# Altium schematic sheet SCM20260D-Connectors.SchDoc(SCM20260D-Connectors)
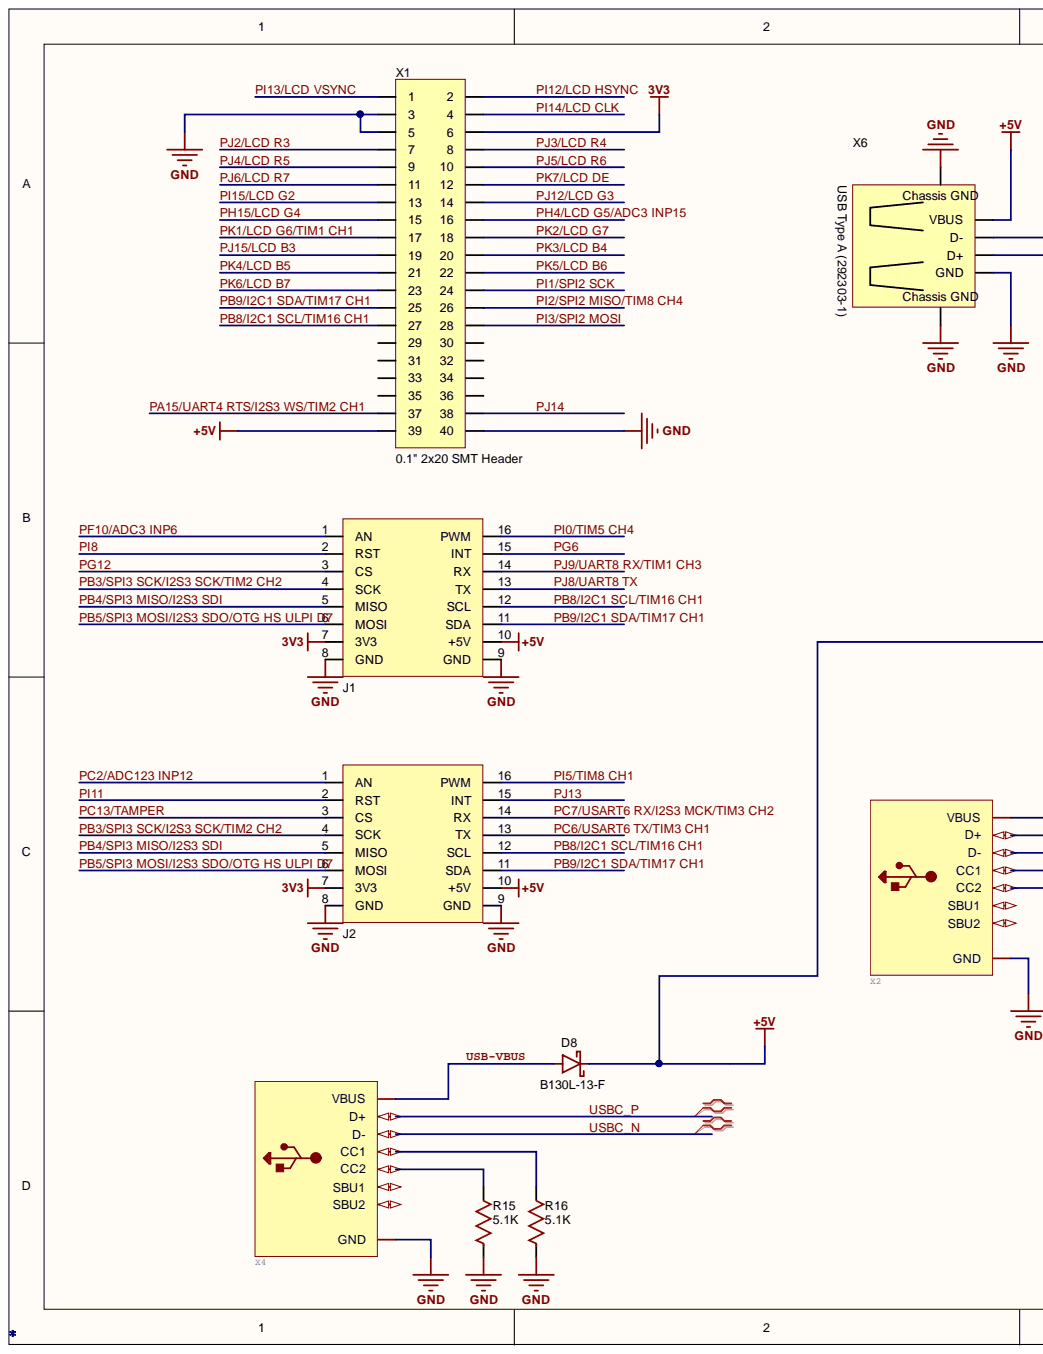
[diagram p0: SCM20260D-Connectors.SchDoc, left]
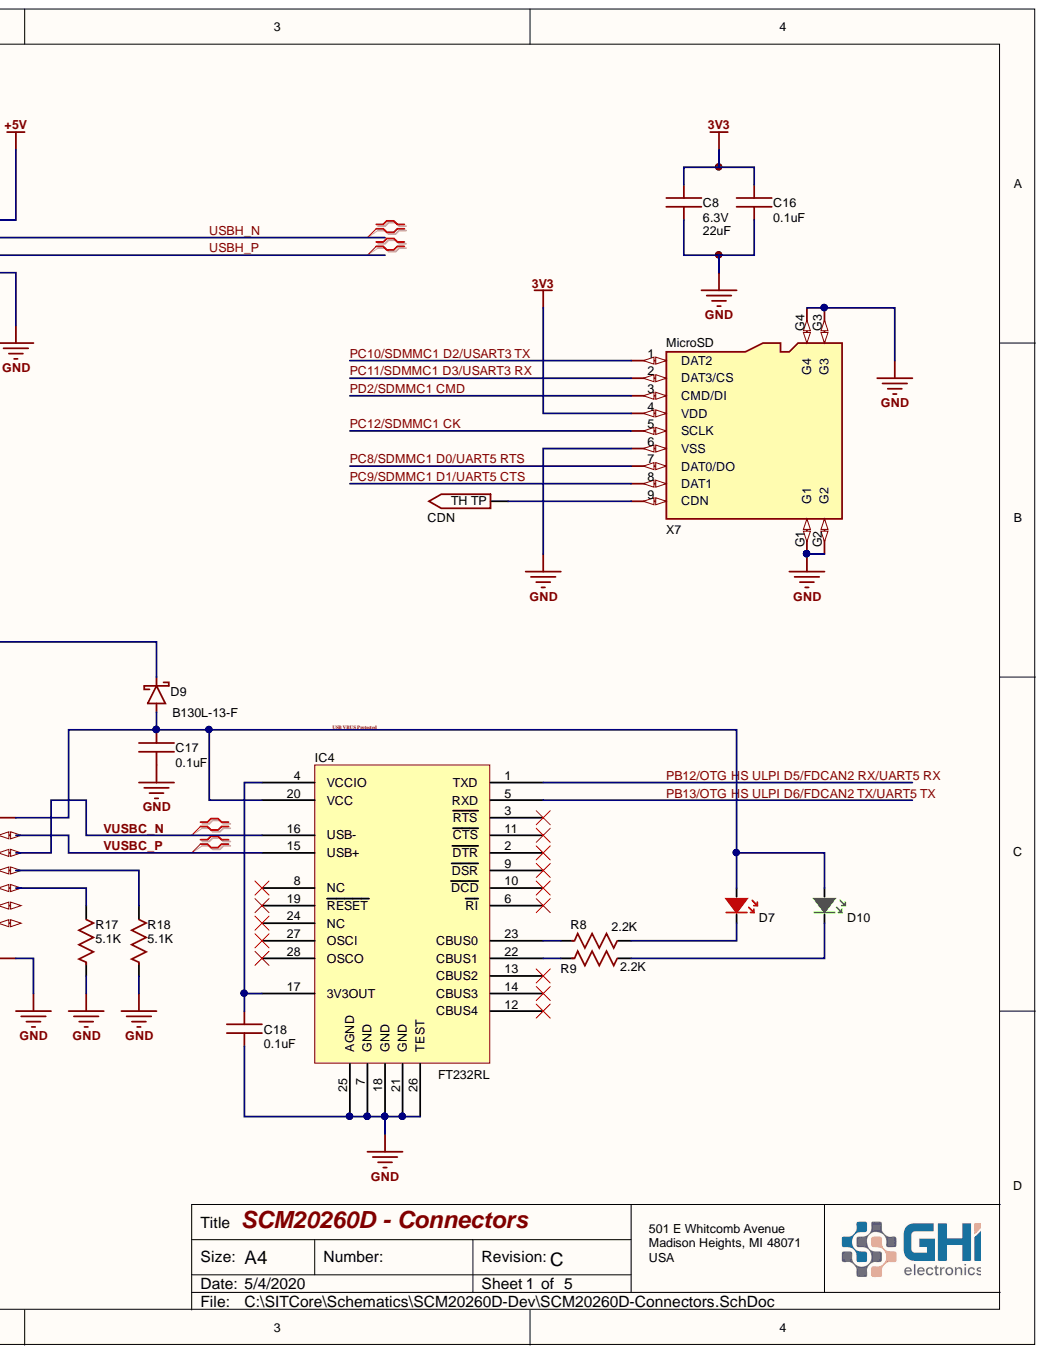
[diagram p1: SCM20260D-Connectors.SchDoc, right]

## Parts
C8  value 22uF  Voltage: 6.3V  [C0805]  (hidden comment: 0805 Cap 22uF)
C16  value 0.1uF  [C0603 (1608 Metric)]  (hidden comment: 0603 Cap 0.1uF)
C17  value 0.1uF  [C0603 (1608 Metric)]  (hidden comment: 0603 Cap 0.1uF)
C18  value 0.1uF  [C0603 (1608 Metric)]  (hidden comment: 0603 Cap 0.1uF)
CDN  TH Testpad 32mil (32mil ar)  [32mil TH]  (value not drawn)  (no BOM)
D7  0603 LED Red  [0603 LED Red]  (value not drawn)
D8  B130L-13-F  [SMA]
D9  B130L-13-F  [SMA]
D10  0603 LED Green  [0603 LED Green]  (value not drawn)
IC4  FT232RL  [SSOP28]
J1  mikroBUS SMT  [mikroBus - SMT]  (value not drawn)
J2  mikroBUS SMT  [mikroBus - SMT]  (value not drawn)
R8  value 2.2K  [R0603 (1608 Metric)]  (hidden comment: 0603 2.2K)
R9  value 2.2K  [R0603 (1608 Metric)]  (hidden comment: 0603 2.2K)
R15  value 5.1K  [R0402]  (hidden comment: 0402 Res 5.1K)
R16  value 5.1K  [R0402]  (hidden comment: 0402 Res 5.1K)
R17  value 5.1K  [R0402]  (hidden comment: 0402 Res 5.1K)
R18  value 5.1K  [R0402]  (hidden comment: 0402 Res 5.1K)
X1  0.1" 2x20 SMT Header  [UDM435]
X2  (no value)  [GCT_USB4105_revA]
X4  (no value)  [GCT_USB4105_revA]
X6  USB Type A (292303-1)  [AMP 292303-1]
X7  MICROSD  [MICROSD]

## Nets
3V3: C8.2 C16.2 J1.7(3V3) J2.7(3V3) X1.6 X7.4(VDD)
+5V: D8.K D9.K J1.10(+5V) J2.10(+5V) X1.39 X6.1(VBUS)
GND: C8.1 C16.1 C17.1 C18.1 IC4.7(GND) IC4.18(GND) IC4.21(GND) IC4.25(AGND) IC4.26(TEST) J1.8(GND) J1.9(GND) J2.8(GND) J2.9(GND) R15.1 R16.1 R17.1 R18.1 X1.3 X1.5 X1.40 X2.GND X4.GND X6.4(GND) X6.CG1 X6.CG2 X7.6(VSS) X7.G1 X7.G2 X7.G3 X7.G4
PA15/UART4 RTS/I2S3 WS/TIM2 CH1: X1.37
PB3/SPI3 SCK/I2S3 SCK/TIM2 CH2: J1.4(SCK) J2.4(SCK)
PB4/SPI3 MISO/I2S3 SDI: J1.5(MISO) J2.5(MISO)
PB5/SPI3 MOSI/I2S3 SDO/OTG HS ULPI D7: J1.6(MOSI) J2.6(MOSI)
PB8/I2C1 SCL/TIM16 CH1: J1.12(SCL) J2.12(SCL) X1.27
PB9/I2C1 SDA/TIM17 CH1: J1.11(SDA) J2.11(SDA) X1.25
PB12/OTG HS ULPI D5/FDCAN2 RX/UART5 RX: IC4.1(TXD)
PB13/OTG HS ULPI D6/FDCAN2 TX/UART5 TX: IC4.5(RXD)
PC2/ADC123 INP12: J2.1(AN)
PC6/USART6 TX/TIM3 CH1: J2.13(TX)
PC7/USART6 RX/I2S3 MCK/TIM3 CH2: J2.14(RX)
PC8/SDMMC1 D0/UART5 RTS: X7.7(DAT0/DO)
PC9/SDMMC1 D1/UART5 CTS: X7.8(DAT1)
PC10/SDMMC1 D2/USART3 TX: X7.1(DAT2)
PC11/SDMMC1 D3/USART3 RX: X7.2(DAT3/CS)
PC12/SDMMC1 CK: X7.5(SCLK)
PC13/TAMPER: J2.3(CS)
PD2/SDMMC1 CMD: X7.3(CMD/DI)
PF10/ADC3 INP6: J1.1(AN)
PG6: J1.15(INT)
PG12: J1.3(CS)
PH4/LCD G5/ADC3 INP15: X1.16
PH15/LCD G4: X1.15
PI0/TIM5 CH4: J1.16(PWM)
PI1/SPI2 SCK: X1.24
PI2/SPI2 MISO/TIM8 CH4: X1.26
PI3/SPI2 MOSI: X1.28
PI5/TIM8 CH1: J2.16(PWM)
PI8: J1.2(RST)
PI11: J2.2(RST)
PI12/LCD HSYNC: X1.2
PI13/LCD VSYNC: X1.1
PI14/LCD CLK: X1.4
PI15/LCD G2: X1.13
PJ2/LCD R3: X1.7
PJ3/LCD R4: X1.8
PJ4/LCD R5: X1.9
PJ5/LCD R6: X1.10
PJ6/LCD R7: X1.11
PJ8/UART8 TX: J1.13(TX)
PJ9/UART8 RX/TIM1 CH3: J1.14(RX)
PJ12/LCD G3: X1.14
PJ13: J2.15(INT)
PJ14: X1.38
PJ15/LCD B3: X1.19
PK1/LCD G6/TIM1 CH1: X1.17
PK2/LCD G7: X1.18
PK3/LCD B4: X1.20
PK4/LCD B5: X1.21
PK5/LCD B6: X1.22
PK6/LCD B7: X1.23
PK7/LCD DE: X1.12
USB VBUS Protected: C17.2 D7.2 D9.A D10.2 IC4.20(VCC) X2.A4B9(VBUS)
USB-VBUS: D8.A X4.A4B9(VBUS)
USBC_N: X4.A7B7(D-)
USBC_P: X4.A6B6(D+)
USBH_N: X6.2(D-)
USBH_P: X6.3(D+)
VUSBC_N: IC4.16(USB-) X2.A7B7(D-)
VUSBC_P: IC4.15(USB+) X2.A6B6(D+)
NetC18_2: C18.2 IC4.4(VCCIO) IC4.17(3V3OUT)
NetCDN_1: CDN.1 X7.9(CDN)
NetD7_1: D7.1 R8.2
NetD10_1: D10.1 R9.2
NetIC4_22: IC4.22(CBUS1) R9.1
NetIC4_23: IC4.23(CBUS0) R8.1
NetR15_2: R15.2 X4.B5(CC2)
NetR16_2: R16.2 X4.A5(CC1)
NetR17_2: R17.2 X2.B5(CC2)
NetR18_2: R18.2 X2.A5(CC1)

Not connected: IC4 2(DTR) 3(RTS) 6(RI) 8(NC) 9(DSR) 10(DCD) 11(CTS) 12(CBUS4) 13(CBUS2) 14(CBUS3) 19(RESET) 24(NC) 27(OSCI) 28(OSCO); X1 29 30 31 32 33 34 35 36; X2 A8(SBU1) B8(SBU2); X4 A8(SBU1) B8(SBU2)

## Library data of the parts
C8 = 0805 Cap 22uF: 22uF ±20% 6.3V X5R 0805 Multilayer Ceramic Capacitors MLCC - SMD/SMT RoHS; Mfr.Part #: CL21A226MQCLRNC; Tolerance: ±20%; Package: 0805; LCSC Part #: C307530; Component Kind: Standard; Category: Ceramic; Capacitance: 22uF; Library Name: GHI-Passives.SchLib
C16-C18 = 0603 Cap 0.1uF: 0.1uF ±5% 50V X7R 0603 Multilayer Ceramic Capacitors MLCC - SMD/SMT RoHS; Mfr.Part #: CL10B104JB8NNNC; Voltage: 50V; Tolerance: ±5%; Package: 0603; LCSC Part #: C24452; Digikey: 1276-1033-1-ND; Component Kind: Standard; Category: Ceramic; Capacitance: 0.1uF; Library Name: GHI-Passives.SchLib
CDN = TH Testpad 32mil (32mil ar): TH Testpad 32mil (32mil annular ring); Library Name: GHI.SchLib
D7 = 0603 LED Red: Red 631nm 0603 Light Emitting Diodes (LED) RoHS; Mfr.Part #: LTST-C193KRKT-5A; Manufacturer: Lite-On; Value: 0603 LED Red; Package: 0603; LCSC Part #: C157741; Component Kind: Standard; Color: Red; Library Name: GHI-Opto.SchLib
D8 D9 = B130L-13-F 1A Schottky SMA: 30V 1A 410mV @ 1A SMA(DO-214AC) Schottky Barrier Diodes (SBD) RoHS; Manufacturer: Diodes Incorporated; GHI Part Number: EPC-DB130L-13; Value: B130L-13-F; Package: SMA(DO-214AC); Mfr.Part #: B130L-13-F; Library Name: GHI.SchLib; Component Type: Diode; Component Kind: Standard
D10 = 0603 LED Green: Green 567.5~576.5nm 0603 Light Emitting Diodes (LED) RoHS; Mfr.Part #: LTST-C193KGKT-5A; Manufacturer: Lite-On; Value: 0603 LED Green; Package: 0603; LCSC Part #: C125102; Component Kind: Standard; Color: Green; Library Name: GHI-Opto.SchLib
IC4 = FT232RL: Future Technology Devices International Ltd. FT232R USB UART IC; Value: FT232RL; PartNo: FT232RL; Component Kind: Standard; Library Name: GHI IC.SchLib
J1 J2 = mikroBUS SMT: Value: 218108M141; PartNo: 218108M141; Component Kind: Standard; Library Name: GHI.SchLib
R8 R9 = 0603 Res 2.2K: 2.2K ±1% 1/10W ±100ppm/℃ 0603 Chip Resistor - Surface Mount RoHS; Mfr.Part #: RC0603FR-072K2L; Manufacturer: YAGEO; Voltage Rating: *V; Resistance Tolerance: ±1%; Resistance: 2.2K; Power Rating: 1/10W; Package: 0603; LCSC Part #: C114662; Component Kind: Standard; Library Name: GHI-Passives.SchLib
R15-R18 = 0402 Res 5.1K: 5.1K ±1% 1/16W ±100ppm/℃ 0402 Chip Resistor - Surface Mount RoHS; Mfr.Part #: RC0402FR-075K1L; Manufacturer: YAGEO; Voltage Rating: *V; Resistance Tolerance: ±1%; Resistance: 5.1K; Power Rating: 1/16W; Package: 0402; LCSC Part #: C105872; Component Kind: Standard; Library Name: GHI-Passives.SchLib
X1 = 2x20 Pin 0.1" SMT: Value: 2x20 Pin 0.1" SMT; Description: 2x20 Pin 0.1" SMT; Component Kind: Standard; Library Name: GHI.SchLib
X2 X4 = USB - C: USB - C (Type - C) USB 2.0 Receptacle Connector 24 (16+8 Dummy) Position Surface Mount, Right Angle; Through Hole; Manufacturer: GCT; Value: USB4105-GF-A; Mfr.Part #: USB4105-GF-A; LCSC Part #: C319148; Digi-Key Part Number: 2073-USB4105-GF-ATR-ND; Component Kind: Standard; : USB - C; Library Name: GHI-Connectors.SchLib
X6 = USB Type A Receptacle: TE Connectivity 292303-1 USB Type A receptacle; Value: 292303-1; PartNo: 292303-1; Component Kind: Standard; Library Name: GHI Sch.SchLib
X7 = MICROSD: Value: MicroSD; PartNo: MicroSD; Component Kind: Standard; Library Name: GHI.SchLib
